# Altium schematic sheet Driver_v0.SchDoc(Driver_v0)
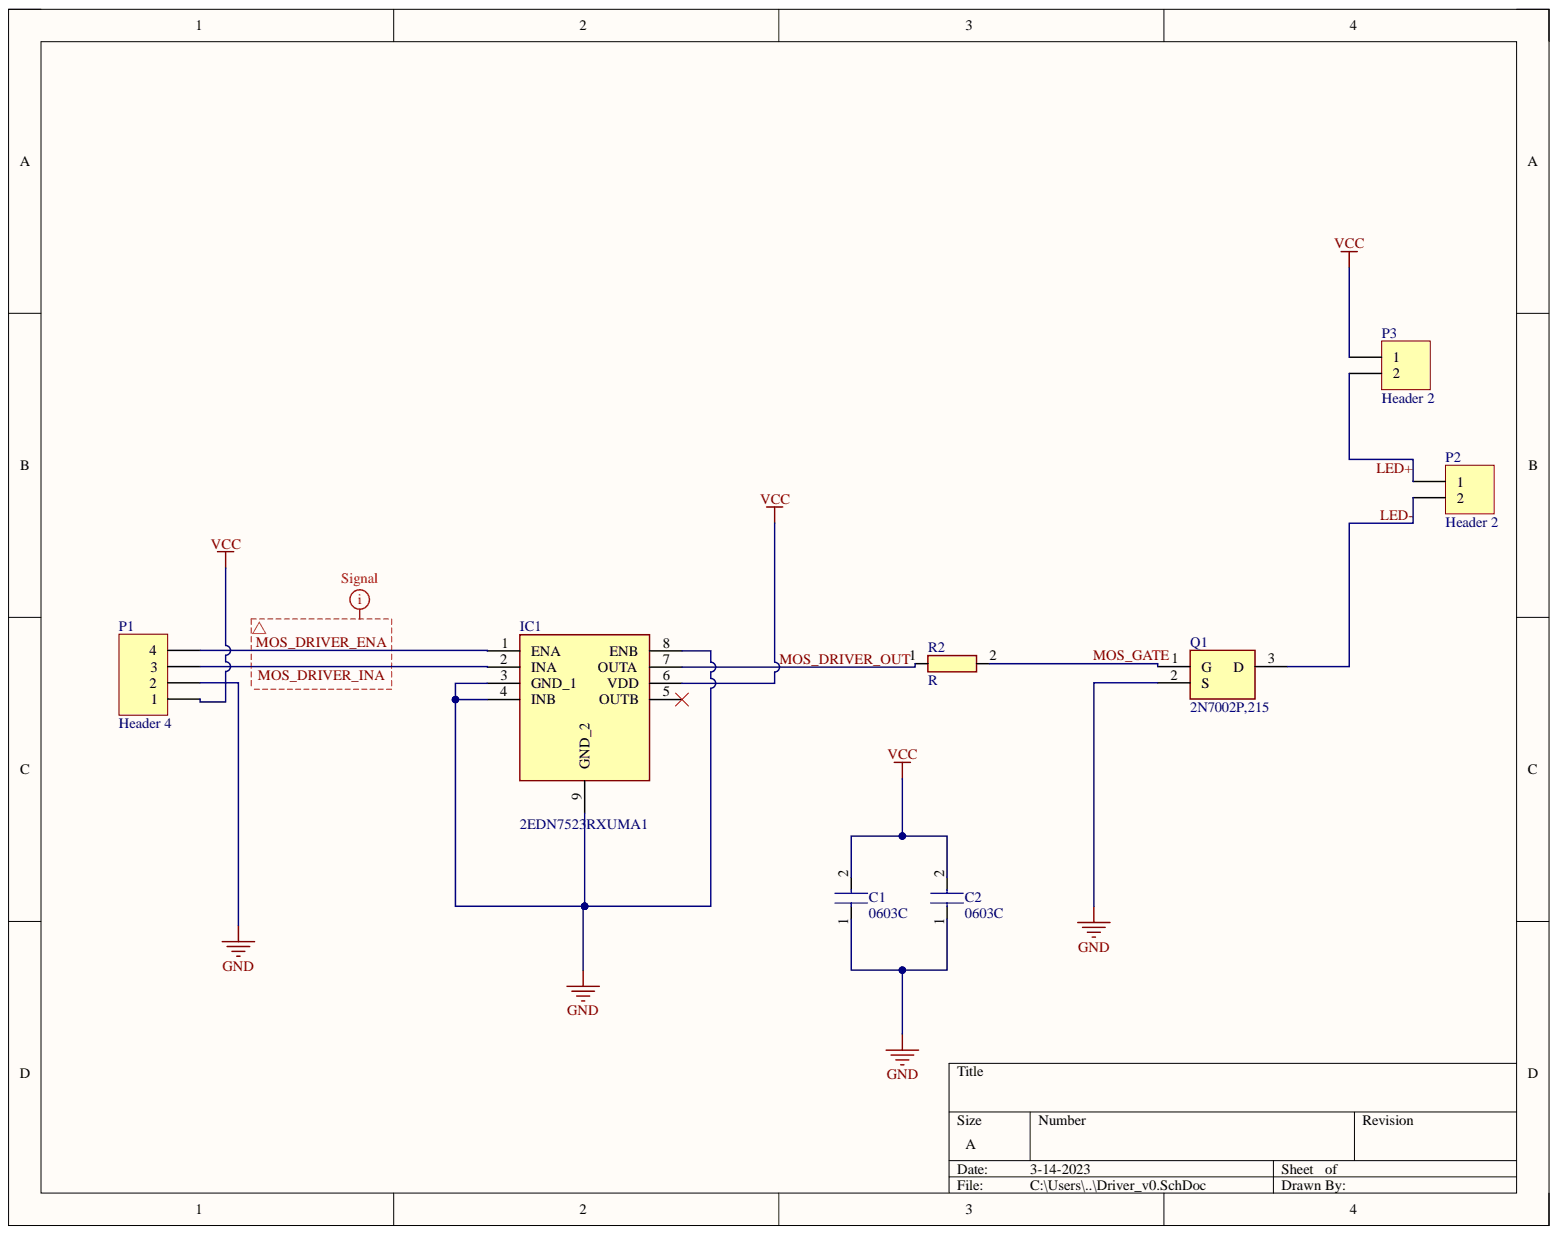
[diagram p0: Driver_v0.SchDoc]

## Parts
C1  0603C  [0603C]
C2  0603C  [0603C]
IC1  2EDN7523RXUMA1  [SOP65P490X104-9N]
P1  Header 4  [HDR1X4]
P2  Header 2  [HDR1X2]
P3  Header 2  [HDR1X2]
Q1  2N7002P,215  [SOT95P230X110-3N]
R2  R  [0603R]

## Nets
GND: C1.1 C2.1 IC1.3(GND_1) IC1.4(INB) IC1.8(ENB) IC1.9(GND_2) P1.2 Q1.2
VCC: C1.2 C2.2 IC1.6(VDD) P1.1 P3.1
LED+: P2.1 P3.2
LED-: P2.2 Q1.3
MOS_DRIVER_ENA: IC1.1(ENA) P1.4
MOS_DRIVER_INA: IC1.2(INA) P1.3
MOS_DRIVER_OUT: IC1.7(OUTA) R2.1
MOS_GATE: Q1.1 R2.2

Not connected: IC1 5(OUTB)

## Library data of the parts
IC1 = 2EDN7523RXUMA1: Integrated Circuit; Manufacturer_Name: Infineon; Arrow Part Number: 2EDN7523RXUMA1; Arrow Price/Stock: https://www.arrow.com/en/products/2edn7523rxuma1/infineon-technologies-ag?region=nac; Component Kind: Standard; Datasheet Link: https://www.infineon.com/dgdl/Infineon-2EDN752x-2EDN852x-DS--DS-v02_05-EN.pdf?fileId=5546d462525dbac40152abcc7dbb1727; Height: 1.04mm; Library Name: led_driver.SchLib; Manufacturer_Part_Number: 2EDN7523RXUMA1; Mouser Part Number: 726-2EDN7523RXUMA1; Mouser Price/Stock: https://www.mouser.co.uk/ProductDetail/Infineon-Technologies/2EDN7523RXUMA1?qs=QIiuLP2W41C%2FmI0Fq5IeEg%3D%3D
P1 = Header 4: Header, 4-Pin; Component Kind: Standard; LatestRevisionDate: 17-Jul-2002; LatestRevisionNote: Re-released for DXP Platform.; Library Name: Miscellaneous Connectors.IntLib; Signal Integrity: Connector; Publisher: Altium Limited
P2 P3 = Header 2: Header, 2-Pin; Component Kind: Standard; LatestRevisionDate: 17-Jul-2002; LatestRevisionNote: Re-released for DXP Platform.; Library Name: Miscellaneous Connectors.IntLib; Signal Integrity: Connector; Publisher: Altium Limited
Q1 = 2N7002P,215: Transistor; Manufacturer_Name: Nexperia; Arrow Part Number: 2N7002P,215; Arrow Price/Stock: https://www.arrow.com/en/products/2n7002p215/nexperia?region=nac; Component Kind: Standard; Datasheet Link: https://assets.nexperia.com/documents/data-sheet/2N7002P.pdf; Height: 1.1mm; Library Name: led_driver.SchLib; Manufacturer_Part_Number: 2N7002P,215; Mouser Part Number: 771-2N7002P-215; Mouser Price/Stock: https://www.mouser.co.uk/ProductDetail/Nexperia/2N7002P215?qs=8%252BCzCWLBsnApRmr7Ok62Hg%3D%3D
R2 = 0603R: Component Kind: Standard; Library Name: led_driver.SchLib
C1 C2 = 0603C: Component Kind: Standard; Library Name: led_driver.SchLib
